# Altium schematic sheet Schematics.SchDoc
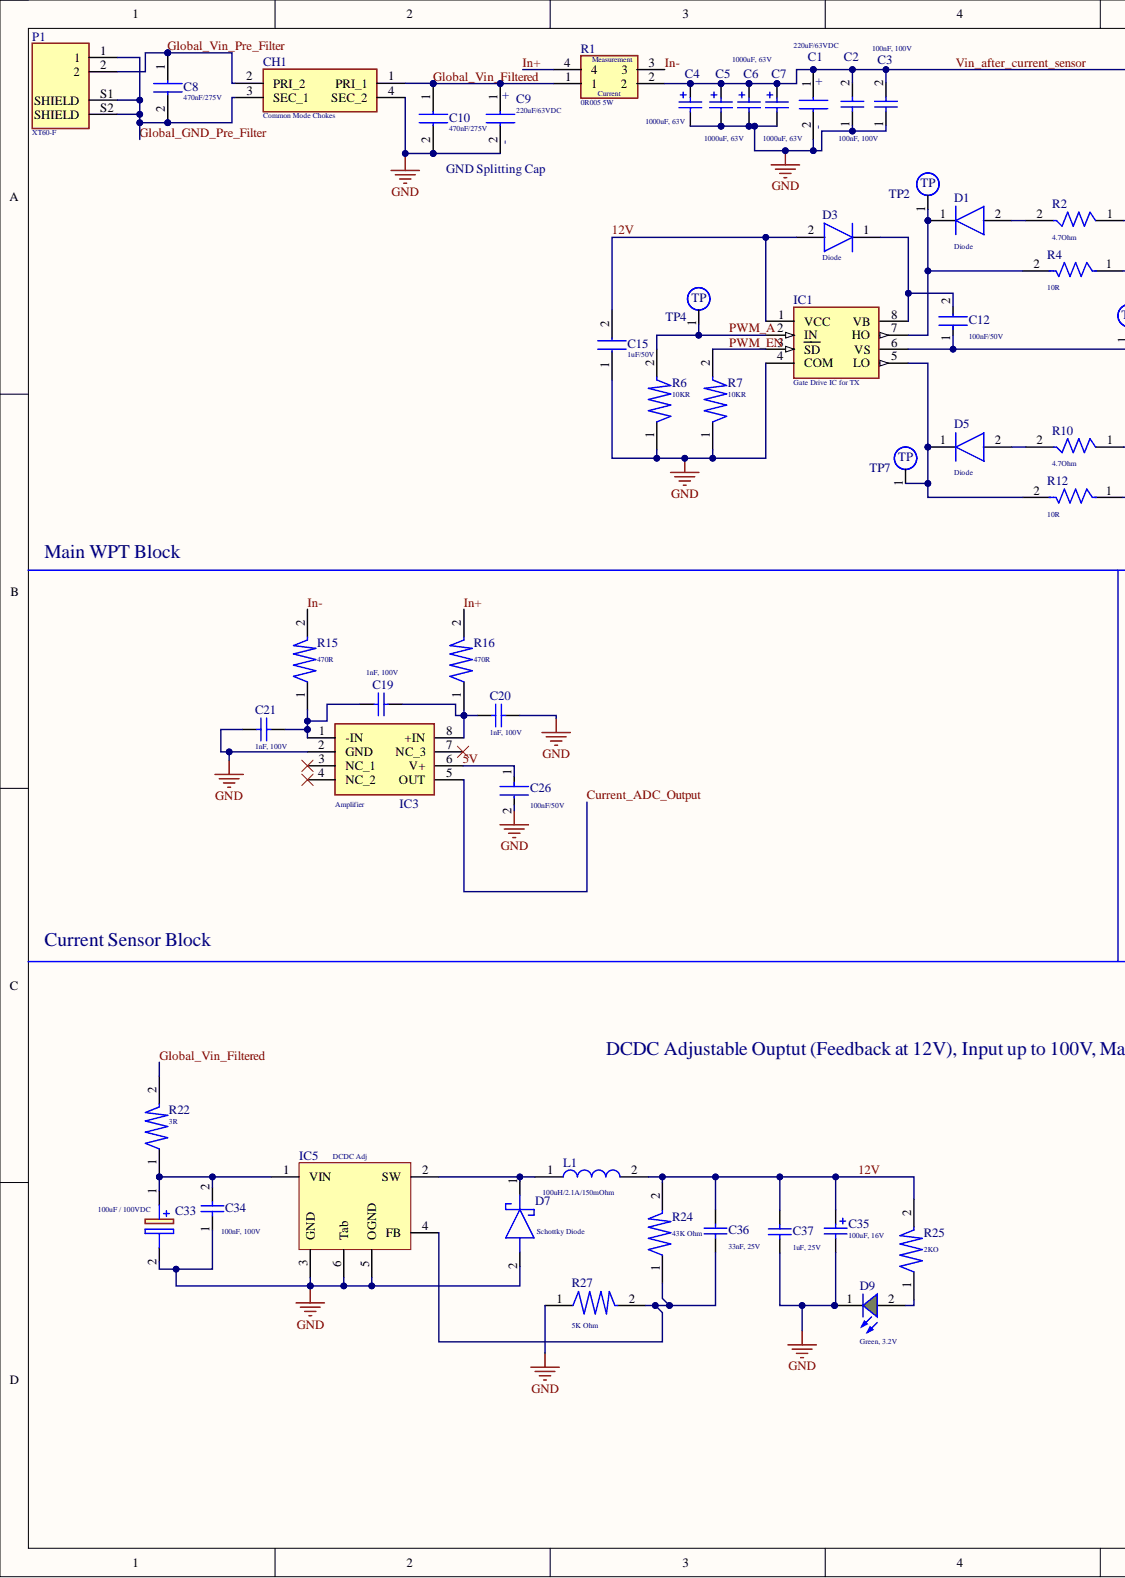
[diagram p0: Schematics.SchDoc, left]
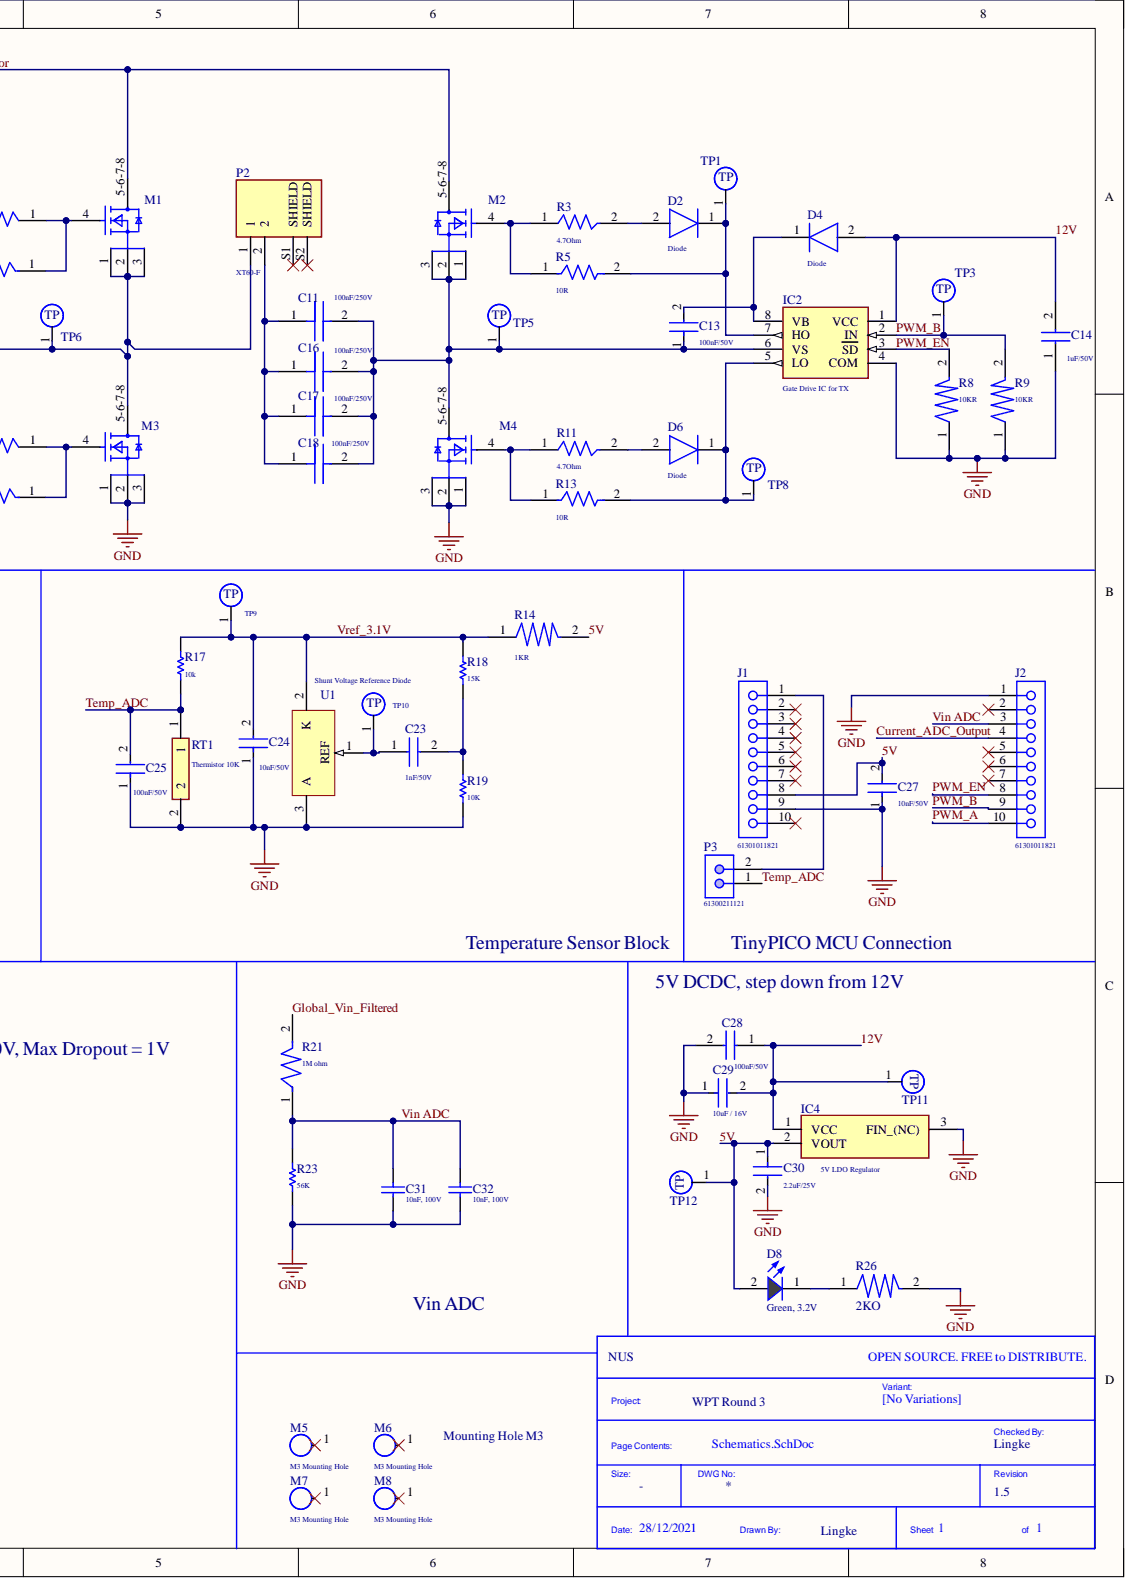
[diagram p1: Schematics.SchDoc, right]

## Parts
*1  Checked By: Lingke  Company: NUS  Project Name: WPT Round 3  Revision: 1.5  (hidden comment: Title_Block)  (no BOM)
C1  220uF/63VDC  [CAPPRD500W62D1000H2650]
C2  100nF, 100V  [WCAP-CSGP_0805_H=1.25mm]
C3  100nF, 100V  [WCAP-CSGP_0805_H=1.25mm]
C4  1000uF, 63V  [WCAP-ATG8_D16H25]
C5  1000uF, 63V  [WCAP-ATG8_D16H25]
C6  1000uF, 63V  [WCAP-ATG8_D16H25]
C7  1000uF, 63V  [WCAP-ATG8_D16H25]
C8  470nF/275V  [890324025039CS]
C9  220uF/63VDC  [CAPPRD500W62D1000H2650]
C10  470nF/275V  [890324025039CS]
C11  100nF/250V  [CAPC4532X220N]
C12  100nF/50V  [0805 Capacitor]
C13  100nF/50V  [0805 Capacitor]
C14  1uF/50V  [CAPC2012X135N]
C15  1uF/50V  [CAPC2012X135N]
C16  100nF/250V  [CAPC4532X220N]
C17  100nF/250V  [CAPC4532X220N]
C18  100nF/250V  [CAPC4532X220N]
C19  1nF, 100V  [WCAP-CSGP_0805_H=0.8mm_Reflow]
C20  1nF, 100V  [WCAP-CSGP_0805_H=0.8mm_Reflow]
C21  1nF, 100V  [WCAP-CSGP_0805_H=0.8mm_Reflow]
C23  1nF/50V  [CAPC2012X90N]
C24  10nF/50V  [0805 Capacitor]
C25  100nF/50V  [0805 Capacitor]
C26  100nF/50V  [0805 Capacitor]
C27  10nF/50V  [0805 Capacitor]
C28  100nF/50V  [CAPC2012X90N]
C29  10uF / 16V  [0805 Capacitor]
C30  2.2uF/25V  [0805 Capacitor]
C31  10nF, 100V  [WCAP-CSGP_0805_H=0.8mm_Reflow]
C32  10nF, 100V  [WCAP-CSGP_0805_H=0.8mm_Reflow]
C33  100uF / 100VDC  [CAPPRD500W60D1000H2200]
C34  100nF, 100V  [WCAP-CSGP_0805_H=1.25mm]
C35  100uF, 16V  [WCAP-ASLI_D6.3H5.5]
C36  33nF, 25V  [WCAP-CSGP_0805_H=0.8mm_Reflow]
C37  1uF, 25V  [WCAP-CSGP_0805_H=1.25mm]
CH1  Common Mode Chokes  [PA5141205NLT]
D1  Diode  [SOD3716X135N]
D2  Diode  [SOD3716X135N]
D3  Diode  [SOD3716X135N]
D4  Diode  [SOD3716X135N]
D5  Diode  [SOD3716X135N]
D6  Diode  [SOD3716X135N]
D7  Schottky Diode  [DIONM8059X265N]
D8  Green, 3.2V  Forward Voltage typ.: 3.2V  [WL-SMCW_0805]
D9  Green, 3.2V  Forward Voltage typ.: 3.2V  [WL-SMCW_0805]
IC1  Gate Drive IC for TX  [SOIC127P600X175-8N]
IC2  Gate Drive IC for TX  [SOIC127P600X175-8N]
IC3  Amplifier  [SOIC127P600X175-8N]
IC4  5V LDO Regulator  [ROHM_TO-252-3]
IC5  DCDC Adj  [TO-263-5]
J1  61301011821  [61301011821]
J2  61301011821  [61301011821]
L1  100uH/2.1A/150mOhm  [INDPM125125X800N]
M1  Mosfet  [FP-PG-TDSON-8-MFG]  (value not drawn)
M2  Mosfet  [FP-PG-TDSON-8-MFG]  (value not drawn)
M3  Mosfet  [FP-PG-TDSON-8-MFG]  (value not drawn)
M4  Mosfet  [FP-PG-TDSON-8-MFG]  (value not drawn)
M5  M3 Mounting Hole  [M3 Hole]
M6  M3 Mounting Hole  [M3 Hole]
M7  M3 Mounting Hole  [M3 Hole]
M8  M3 Mounting Hole  [M3 Hole]
P1  XT60-F  [AMASS_XT60PW-F]
P2  XT60-F  [AMASS_XT60PW-F]
P3  61300211121  [61300211121]
R1  0R005 5W  [FC4L110R005FER]
R2  4.7Ohm  [FP-CRCW1206-e3-IPC_A]
R3  4.7Ohm  [FP-CRCW1206-e3-IPC_A]
R4  10R  [FP-CRCW1206-e3-IPC_A]
R5  10R  [FP-CRCW1206-e3-IPC_A]
R6  10KR  [FP-CRCW0805-e3-MFG]
R7  10KR  [FP-CRCW0805-e3-MFG]
R8  10KR  [FP-CRCW0805-e3-MFG]
R9  10KR  [FP-CRCW0805-e3-MFG]
R10  4.7Ohm  [FP-CRCW1206-e3-IPC_A]
R11  4.7Ohm  [FP-CRCW1206-e3-IPC_A]
R12  10R  [FP-CRCW1206-e3-IPC_A]
R13  10R  [FP-CRCW1206-e3-IPC_A]
R14  1KR  [FP-CRCW0805-e3-MFG]
R15  470R  [FP-CRCW0805-e3-MFG]
R16  470R  [FP-CRCW0805-e3-MFG]
R17  10k  [0805 Resistor]
R18  15K  [0805 Resistor]
R19  10K  [0805 Resistor]
R21  1M ohm  [FP-CRCW0805-e3-MFG]
R22  3R  [1206_Resistor]
R23  56K  [0805 Resistor]
R24  43K Ohm  [FP-CRCW0805-e3-MFG]
R25  2KO  [FP-CRCW0805-e3-MFG]
R26  2KO  [FP-CRCW0805-e3-MFG]
R27  5K Ohm  [FP-CRCW0805-e3-MFG]
RT1  Thermistor 10K  [THRMC2012X95N]
TP1  TP  [Test Point]  (no BOM)
TP2  TP  [Test Point]  (no BOM)
TP3  TP  [Test Point]  (no BOM)
TP4  TP  [Test Point]  (no BOM)
TP5  TP  [Test Point]  (no BOM)
TP6  TP  [Test Point]  (no BOM)
TP7  TP  [Test Point]  (no BOM)
TP8  TP  [Test Point]  (no BOM)
TP9  TP  [Test Point]  (no BOM)
TP10  TP  [Test Point]  (no BOM)
TP11  TP  [Test Point]  (no BOM)
TP12  TP  [Test Point]  (no BOM)
U1  Shunt Voltage Reference Diode  [SOT23-3_N]

## Nets
GND: C1.2 C2.1 C3.1 C4.2 C5.2 C6.2 C7.2 C9.2 C10.2 C14.1 C15.1 C20.2 C21.1 C24.1 C25.1 C26.2 C27.1 C28.2 C29.1 C30.2 C31.1 C32.1 C33.2 C34.1 C35.2 C37.1 CH1.4(SEC_2) D7.2 D9.1 IC1.4(COM) IC2.4(COM) IC3.2(GND) IC4.3 IC5.3(GND) IC5.5(OGND) IC5.6(Tab) J1.9 J2.1 M3.1 M3.2 M3.3 M4.1 M4.2 M4.3 R6.1 R7.1 R8.1 R9.1 R19.1 R23.1 R26.2 R27.1 RT1.2 U1.3
5V: C26.1 C27.2 C30.1 D8.2 IC3.6(V+) IC4.2 J1.8 R14.2 TP12.1
12V: C14.2 C15.2 C28.1 C29.2 C35.1 C36.2 C37.2 D3.2 D4.2 IC1.1(VCC) IC2.1(VCC) IC4.1 L1.2 R24.2 R25.2 TP11.1
Current_ADC_Output: IC3.5(OUT) J2.4
Global_GND_Pre_Filter: C8.2 CH1.3(SEC_1) P1.1 P1.S1(SHIELD) P1.S2(SHIELD)
Global_Vin_Filtered: C9.1 C10.1 CH1.1(PRI_1) R1.1 R21.2 R22.2
Global_Vin_Pre_Filter: C8.1 CH1.2(PRI_2) P1.2
In+: R1.4 R16.2
In-: R1.3 R15.2
PWM_A: IC1.2(IN) J2.10 R6.2 TP4.1
PWM_B: IC2.2(IN) J2.9 R9.2 TP3.1
PWM_EN: IC1.3(SD) IC2.3(SD) J2.8 R7.2 R8.2
Temp_ADC: C25.2 P3.1 R17.1 RT1.1
Vin ADC: C31.2 C32.2 J2.3 R21.1 R23.2
Vin_after_current_sensor: C1.1 C2.2 C3.2 C4.1 C5.1 C6.1 C7.1 M1.5-6-7-8 M2.5-6-7-8 R1.2
Vref_3.1V: C24.2 R14.1 R17.2 R18.2 TP9.1 U1.2
NetC11_1: C11.1 C16.1 C17.1 C18.1 P2.2
NetC11_2: C11.2 C13.1 C16.2 C17.2 C18.2 IC2.6(VS) M2.1 M2.2 M2.3 M4.5-6-7-8 TP5.1
NetC12_1: C12.1 IC1.6(VS) M1.1 M1.2 M1.3 M3.5-6-7-8 P2.1 TP6.1
NetC12_2: C12.2 D3.1 IC1.8(VB)
NetC13_2: C13.2 D4.1 IC2.8(VB)
NetC19_1: C19.1 C21.2 IC3.1(-IN) R15.1
NetC19_2: C19.2 C20.1 IC3.8(+IN) R16.1
NetC23_1: C23.1 TP10.1 U1.1
NetC23_2: C23.2 R18.1 R19.2
NetC33_1: C33.1 C34.2 IC5.1(VIN) R22.1
NetC36_1: C36.1 IC5.4(FB) R24.1 R27.2
NetD1_1: D1.1 IC1.7(HO) R4.2 TP2.1
NetD1_2: D1.2 R2.2
NetD2_1: D2.1 IC2.7(HO) R5.2 TP1.1
NetD2_2: D2.2 R3.2
NetD5_1: D5.1 IC1.5(LO) R12.2 TP7.1
NetD5_2: D5.2 R10.2
NetD6_1: D6.1 IC2.5(LO) R13.2 TP8.1
NetD6_2: D6.2 R11.2
NetD7_1: D7.1 IC5.2(SW) L1.1
NetD8_1: D8.1 R26.1
NetD9_2: D9.2 R25.1
NetJ1_1: J1.1 P3.2
NetM1_4: M1.4 R2.1 R4.1
NetM2_4: M2.4 R3.1 R5.1
NetM3_4: M3.4 R10.1 R12.1
NetM4_4: M4.4 R11.1 R13.1

Not connected: IC3 3(NC_1) 4(NC_2) 7(NC_3); J1 2 3 4 5 6 7 10; J2 2 5 6 7; M5 1; M6 1; M7 1; M8 1; P2 S1(SHIELD) S2(SHIELD)

## Library data of the parts
*1 = Title_Block: Standard Title Block.; Library Name: Symbol Library.SchLib; Size: -; Drawn By: Lingke
C1 C9 = 860040775010: Polarised Cap, 220uF/63VDC; Manufacturer_Name: Wurth Elektronik; Component Kind: Standard; Datasheet Link: https://www.mouser.de/datasheet/2/445/860040775010-1725627.pdf; Height: 26.5mm; Library Name: Symbol Library.SchLib; Manufacturer_Part_Number: 860040775010
C2 C3 C34 = 885012207128: WCAP-CSGP Multilayer Ceramic Chip Capacitor, General Purpose, size 0805, X7R, 100nF, 100V; Manufacturer: Wurth Elektronik; Capacitance Tolerance: +/-10%; Dissipation Factor: #NAME?; Isolation Resistance: >=1GOhm; Rated Voltage: 100VDC; Technical Reference: X7R0805104K100DFCT10000; Capacitance: 100nF; Ceramic Type: X7R; Component Kind: Standard; ComponentLink1Description: Manufacturer; ComponentLink1URL: http://www.we-online.de; ComponentLink2Description: Datasheet; ComponentLink2URL: https://www.we-online.com/catalog/datasheet/885012207128.pdf; Height: 1.25mm; Library Name: Symbol Library.SchLib; Manufacturer Part Number: 885012207128; Mounting Technology: SMT; Operating Temperature: -55 to +125degC; Packaging: Tape and Reel; Published Date: May 2020; Size: 0805
C4-C7 = 860010780024: WCAP-ATG8 Aluminum Electrolytic Capacitor, Radial, THT, D16xH25, 1000uF, 63V; Manufacturer: Wurth Elektronik; Capacitance Tolerance: +/-20%; Dissipation Factor: 9%; Endurance: 2000h; Leakage Current: 630uA; Rated Voltage: 63VDC; Ripple Current: 1540mA; Technical Reference: ATBK250102M063DSPAEB000; Capacitance: 1000uF; Component Kind: Standard; ComponentLink1Description: Manufacturer; ComponentLink1URL: http://www.we-online.de; ComponentLink2Description: Datasheet; ComponentLink2URL: https://www.we-online.com/catalog/datasheet/860010780024.pdf; Diameter: 16mm; Height: 25mm; Library Name: Altium_WCAP-ATG8 (rev20b).IntLib; Manufacturer Part Number: 860010780024; Mounting Technology: THT; Operating Temperature: -40 to +85degC; Packaging: Ammo Pack; Published Date: October 2020
C8 C10 = 890324025039CS: Safety Capacitor, 470nF/275V; Manufacturer_Name: Wurth Elektronik; Component Kind: Standard; Height: 14.5mm; Library Name: Symbol Library.SchLib; Manufacturer_Part_Number: 890324025039CS
C11 C16-C18 = C1812C104JAGACAUTO: Manufacturer_Name: Kemet; Component Kind: Standard; Datasheet Link: https://api.kemet.com/component-edge/download/datasheet/C1812C104JAGACAUTO.pdf; Description: 100nF/250V; Height: 2.2mm; Library Name: Symbol Library.SchLib; Manufacturer_Part_Number: C1812C104JAGACAUTO; Supplier 1: Mouser; Supplier Part Number 1: 80-C1812C104JAGAUTO
C12 C13 C25 C26 = 885012207098: Component Kind: Standard; Description: 100nF/50V; Library Name: Symbol Library.SchLib; Supplier 1: Mouser; Supplier Part Number 1: 710-885012207098
C14 C15 = 885012207103: Capacitor 1uF/50V; Manufacturer_Name: Wurth Elektronik; Component Kind: Standard; Datasheet Link: https://katalog.we-online.com/pbs/datasheet/885012207103.pdf; Height: 1.35mm; Library Name: Symbol Library.SchLib; Manufacturer_Part_Number: 885012207103; Supplier 1: Mouser; Supplier Part Number 1: 710-885012207103
C19-C21 = 885012207116: WCAP-CSGP Multilayer Ceramic Chip Capacitor, General Purpose, size 0805, X7R, 1nF, 100V; Manufacturer: Wurth Elektronik; Capacitance Tolerance: +/-10%; Dissipation Factor max.: 2.5%; Isolation Resistance  min.: 10GOhm; Rated Voltage: 100VDC; Technical Reference: X7R0805102K100DFCT10000; Capacitance: 1nF; Ceramic Type: X7R; Component Kind: Standard; ComponentLink1Description: Manufacturer; ComponentLink1URL: http://www.we-online.de; ComponentLink2Description: Datasheet; ComponentLink2URL: https://www.we-online.com/catalog/datasheet/885012207116.pdf; Height: 0.8mm; Library Name: Altium_WCAP-CSGP (rev21d).IntLib; Manufacturer Part Number: 885012207116; Mounting Technology: SMT; Operating Temperature: -55 to +125degC; Packaging: Tape and Reel; Published Date: April 2021; Q-Factor min.: -; Size: 0805
C23 = 885012207086: Manufacturer_Name: Wurth Elektronik; Component Kind: Standard; Datasheet Link: https://katalog.we-online.com/pbs/datasheet/885012207086.pdf; Description: 1nF/50V; Height: 0.9mm; Library Name: Symbol Library.SchLib; Manufacturer_Part_Number: 885012207086; Supplier 1: Mouser; Supplier Part Number 1: 710-885012207086
C24 C27 = 885012207092: Component Kind: Standard; Description: 10nF/50V; Library Name: Symbol Library.SchLib; Supplier 1: Mouser; Supplier Part Number 1: 710-885012207092
C28 = 885012207098: Manufacturer_Name: Wurth Elektronik; Component Kind: Standard; Description: 100nF/50V; Height: 0.9mm; Library Name: Symbol Library.SchLib; Supplier 1: Mouser; Supplier Part Number 1: 710-885012207098
C29 = CL21A106KOQNNNE: 100nF/50V; Component Kind: Standard; Supplier 1: Mouser; Supplier Part Number 1: 710-885012207098; Library Name: WPT NUS.IntLib
C30 = 885012207079: Capacitance: 2.2; Dielectric: X5R; Voltage Rating (DC): 25V; Case Code (Imperial): 0805; Case Code (Metric): 2012; Case/Package: 0805; Component Kind: Standard; Description: 2.2uF/25V; Library Name: Symbol Library.SchLib; Max Operating Temperature: 125°C; Package Quantity: 2000; Supplier 1: Mouser; Supplier Part Number 1: 710-885012207079
C31 C32 = 885012207122: WCAP-CSGP Multilayer Ceramic Chip Capacitor, General Purpose, size 0805, X7R, 10nF, 100V; Manufacturer: Wurth Elektronik; Capacitance Tolerance: +/-10%; Dissipation Factor max.: 2.5%; Isolation Resistance  min.: 10GOhm; Rated Voltage: 100VDC; Technical Reference: X7R0805103K100DFCT10000; Capacitance: 10nF; Ceramic Type: X7R; Component Kind: Standard; ComponentLink1Description: Manufacturer; ComponentLink1URL: http://www.we-online.de; ComponentLink2Description: Datasheet; ComponentLink2URL: https://www.we-online.com/catalog/datasheet/885012207122.pdf; Height: 0.8mm; Library Name: Altium_WCAP-CSGP (rev21d).IntLib; Manufacturer Part Number: 885012207122; Mounting Technology: SMT; Operating Temperature: -55 to +125degC; Packaging: Tape and Reel; Published Date: April 2021; Q-Factor min.: -; Size: 0805
C33 = ESH107M100AH4AA: Capacitor Polarised; Manufacturer_Name: Kemet; Component Kind: Standard; Datasheet Link: https://componentsearchengine.com/Datasheets/1/ESH107M100AH4AA.pdf; Height: 22mm; Manufacturer_Part_Number: ESH107M100AH4AA; Mouser Part Number: 80-ESH107M100AH4AA; Mouser Price/Stock: https://www.mouser.co.uk/ProductDetail/KEMET/ESH107M100AH4AA?qs=gt1LBUVyoHkVRbiIYuZpEw%3D%3D; Library Name: WPT NUS.IntLib
C35 = 865080343009: WCAP-ASLI Aluminum Electrolytic Capacitor, V-Chip, SMT, D6.3xH5.5mm, 100uF, 16V; Manufacturer: Wurth Elektronik; Capacitance Tolerance: +/-20%; Dissipation Factor: 16%; Endurance: 2000h; Leakage Current: 16uA; Rated Voltage: 16VDC; Ripple Current: 255mA; Technical Reference: ASED055101M016DVCTBB000; Capacitance: 100uF; Component Kind: Standard; ComponentLink1Description: Manufacturer; ComponentLink1URL: http://www.we-online.de; ComponentLink2Description: Datasheet; ComponentLink2URL: https://www.we-online.com/catalog/datasheet/865080343009.pdf; Diameter: 6.3mm; Height: 5.5mm; Library Name: Altium_WCAP-ASLI (rev20b) (1).IntLib; Manufacturer Part Number: 865080343009; Mounting Technology: SMT; Operating Temperature: -55 to +105degC; Packaging: Tape and Reel; Published Date: October 2020
C36 = 885012207069: WCAP-CSGP Multilayer Ceramic Chip Capacitor, General Purpose, size 0805, X7R, 33nF, 25V; Manufacturer: Wurth Elektronik; Capacitance Tolerance: +/-10%; Dissipation Factor max.: 3.5%; Isolation Resistance  min.: 10GOhm; Rated Voltage: 25VDC; Technical Reference: X7R0805333K025DFCT10000; Capacitance: 33nF; Ceramic Type: X7R; Component Kind: Standard; ComponentLink1Description: Manufacturer; ComponentLink1URL: http://www.we-online.de; ComponentLink2Description: Datasheet; ComponentLink2URL: https://www.we-online.com/catalog/datasheet/885012207069.pdf; Height: 0.8mm; Library Name: Altium_WCAP-CSGP (rev21d).IntLib; Manufacturer Part Number: 885012207069; Mounting Technology: SMT; Operating Temperature: -55 to +125degC; Packaging: Tape and Reel; Published Date: April 2021; Q-Factor min.: -; Size: 0805
C37 = 885012207078: WCAP-CSGP Multilayer Ceramic Chip Capacitor, General Purpose, size 0805, X7R, 1uF, 25V; Manufacturer: Wurth Elektronik; Capacitance Tolerance: +/-10%; Dissipation Factor max.: 5%; Isolation Resistance  min.: 0.5GOhm; Rated Voltage: 25VDC; Technical Reference: X7R0805105K025DFCT10000; Capacitance: 1uF; Ceramic Type: X7R; Component Kind: Standard; ComponentLink1Description: Manufacturer; ComponentLink1URL: http://www.we-online.de; ComponentLink2Description: Datasheet; ComponentLink2URL: https://www.we-online.com/catalog/datasheet/885012207078.pdf; Height: 1.25mm; Library Name: Altium_WCAP-CSGP (rev21d).IntLib; Manufacturer Part Number: 885012207078; Mounting Technology: SMT; Operating Temperature: -55 to +125degC; Packaging: Tape and Reel; Published Date: April 2021; Q-Factor min.: -; Size: 0805
CH1 = PA5141.205NLT: Filter; Manufacturer_Name: Pulse; Component Kind: Standard; Datasheet Link: https://products.pulseelex.com/files/product_files/P860.pdf; Height: 22.7mm; Library Name: Symbol Library.SchLib; Manufacturer_Part_Number: PA5141.205NLT; Mouser Part Number: 673-PA5141.205NLT; Mouser Price/Stock: https://www.mouser.co.uk/ProductDetail/Pulse-Electronics/PA5141205NLT?qs=l7cgNqFNU1hhs8iQ7nyooA%3D%3D
D1-D6 = 1N4148W-E3-08: Manufacturer_Name: Vishay; Component Kind: Standard; Datasheet Link: https://componentsearchengine.com//1N4148W-E3-08.pdf; Description: Diode; Height: 1.35mm; Library Name: Symbol Library.SchLib; Manufacturer_Part_Number: 1N4148W-E3-08; Mouser2 Part Number: 78-1N4148W-E3-08; Mouser2 Price/Stock: https://www.mouser.com/Search/Refine.aspx?Keyword=78-1N4148W-E3-08; Supplier 1: Digi-Key; Supplier Part Number 1: 1N4148W-E3-08CT-ND
D7 = S310: Manufacturer_Name: ON Semiconductor; Arrow Part Number: S310; Arrow Price/Stock: https://www.arrow.com/en/products/s310/on-semiconductor?region=nac; Component Kind: Standard; Datasheet Link: https://componentsearchengine.com/Datasheets/2/S310.pdf; Description: Schottky Diode; Height: 2.65mm; Library Name: Symbol Library.SchLib; Manufacturer_Part_Number: S310; Mouser Part Number: 512-S310; Mouser Price/Stock: https://www.mouser.co.uk/ProductDetail/ON-Semiconductor-Fairchild/S310?qs=AZz8fAaf6P%2FJSlbiibJLxA%3D%3D
D8 D9 = 150080GS75000: WL-SMCW SMT Mono-color Chip LED Waterclear, size 0805, Green, 3.2V, 140deg; Chip Technology: InGaN; Dominant Wavelength typ.: 525nm; Luminous Intensity typ.: 450mcd; Peak Wavelength typ.: 515nm; Component Kind: Standard; ComponentLink1Description: Manufacturer; ComponentLink1URL: http://www.we-online.de; ComponentLink2Description: Datasheet; ComponentLink2URL: https://www.we-online.com/catalog/datasheet/150080GS75000.pdf; Emitting Color: Green; Library Name: Altium_WL-SMCW (rev20a).IntLib; Mounting Technology: SMT; Operating Temperature: -40 to +85degC; Packaging: Tape and Reel; Part Number: 150080GS75000; Published Date: December 2019; Size: 0805; Viewing Angle: 140deg; Publisher: Wurth Elektronik
IC1 IC2 = IRS2008SPBF: Gate Drive IC; Manufacturer_Name: Infineon; Component Kind: Standard; Height: 1.75mm; Library Name: Symbol Library.SchLib; Manufacturer_Part_Number: IRS2008SPBF; Supplier 1: Mouser; Supplier Part Number 1: 726-IRS2008SPBF
IC3 = AD8215YRZ: Integrated Circuit Amplifier; Manufacturer_Name: Analog Devices; Component Kind: Standard; Datasheet Link: http://www.analog.com/static/imported-files/data_sheets/AD8215.pdf; Height: 1.75mm; Library Name: Symbol Library.SchLib; Manufacturer_Part_Number: AD8215YRZ; Supplier 1: Mouser; Supplier Part Number 1: 584-AD8215YRZ
IC4 = BD450M5FP-CE2: Manufacturer_Name: ROHM Semiconductor; Component Kind: Standard; Datasheet Link: https://componentsearchengine.com//BD450M5FP-CE2.pdf; Description: 5V LDO Regulator; Library Name: Symbol Library.SchLib; Manufacturer_Part_Number: BD450M5FP-CE2; Mouser2 Part Number: 755-BD450M5FP-CE2; Mouser2 Price/Stock: https://www.mouser.com/Search/Refine.aspx?Keyword=755-BD450M5FP-CE2
IC5 = XL7035E1: Component Kind: Standard; Library Name: Symbol Library.SchLib
J1 J2 = 61301011821: WR-PHD Socket Header, THT, pitch 2.54mm, Single Row, Vertical, 10p; Manufacturer: Wurth Elektronik; Contact Resistance: 20mOhm; Pin Number: 10; Rated Current: 3A; Withstanding Voltage: 500VAC; Working Voltage: 250VAC; Component Kind: Standard; ComponentLink1Description: Manufacturer; ComponentLink1URL: http://www.we-online.de; ComponentLink2Description: Datasheet; ComponentLink2URL: https://www.we-online.com/catalog/datasheet/61301011821.pdf; Gender: Socket Header; Library Name: Altium_WR-PHD (rev20a).IntLib; Manufacturer Part Number: 61301011821; Mounting Technology: THT; Operating Temperature: -40 to +125degC; Orientation: Vertical; Packaging: Tray; Pitch: 2.54mm; Published Date: May 2020; Row Number: Single
L1 = SRR1280-101M: Inductor; Manufacturer_Name: Bourns; Arrow Part Number: SRR1280-101M; Arrow Price/Stock: https://www.arrow.com/en/products/srr1280-101m/bourns; Component Kind: Standard; Datasheet Link: https://componentsearchengine.com/Datasheets/2/SRR1280-101M.pdf; Height: 8mm; Library Name: Symbol Library.SchLib; Manufacturer_Part_Number: SRR1280-101M; Mouser Part Number: 652-SRR1280-101M; Mouser Price/Stock: https://www.mouser.co.uk/ProductDetail/Bourns/SRR1280-101M?qs=brMhP%2FMjUfd8D85Ep5aW7g%3D%3D
M1-M4 = BSC040N10NS5ATMA1: MOSFET N-CH 100V 100A 8TDSON  Mosfet Switcher; Manufacturer: Infineon; Depletion Mode: False; Drain To Source Resistance: 3.4mΩ; Gate Charge At Vgs: 58nC @ 10V; Gate To Source Voltage: 20V; Input Capacitace At Vds: 4100pF @ 50V; Max Forward Diode Voltage: 1.1V; Max Junction Temp: +150°C; Number Of Channels: 1; Power Dissipation: 139W; Pulse Drain Current: 400A; Reverse Recovery Charge: 90nC; Reverse Recovery Time: 54ns; Rthja Max: 50K/W; Threshold Vgs Max: 3.8V; Threshold Vgs Min: 2.2V; Transconductance: 120S; Turn Off Delay Time: 32ns; Turn On Delay Time: 13ns; Typ Forward Diode Voltage: 0.9V; Automotive: No; Category: Trans; Component Kind: Standard; ComponentLink2Description: Datasheet; ComponentLink2URL: https://www.infineon.com/dgdl/Infineon-BSC040N10NS5-DS-v02_02-EN.pdf?fileId=5546d4624a0bf290014a0fbcee2e6b38; Continuous Drain Current: 100A; Device Class L1: Discrete Semiconductors; Device Class L2: Transistors; Device Class L3: MOSFETs; Digikey Description: MOSFET N-CH 100V 100A 8TDSON; Drain To Source Breakdown Voltage: 100V; Drain To Source Voltage: 100V; Footprint Url: https://www.infineon.com/dgdl/Infineon-PG-TDSON-8-7-FP-v01_00-EN.pdf?fileId=5546d46259d9a4bf015a1b6adadd1f0a; Height: 1.1mm; Lead Free: Yes; Library Name: Symbol Library.SchLib; Manufacturer Part Number: BSC040N10NS5ATMA1; Mouser Description: MOSFET N-Ch 100V 100A TDSON-8; Number Of N Channels: 1; Octopart: https://octopart.com/bsc040n10ns5atma1-infineon-52825711; Package: PG-TDSON-8; RoHS: TRUE; Supplier 1: Mouser; Supplier Part Number 1: 726-BSC040N10NS5ATMA; Temperature: -55°C to +150°C; Temperature Range High: +150°C; Temperature Range Low: -55°C
M5-M8 = M3_Mounting_hole: Component Kind: Standard; Library Name: Symbol Library.SchLib
P1 P2 = XT60PW-F: Socket DC Supply Connector Female; MF: AMASS; Availability: Unavailable; BuyPart: https://www.snapeda.com/parts/XT60PW-F/AMASS/view-part/2890674/?ref=eda; Component Kind: Standard; Library Name: Symbol Library.SchLib; MP: XT60PW-F; Package: Package; Price: None; SnapEDA_Link: https://www.snapeda.com/parts/XT60PW-F/AMASS/view-part/2890674/?ref=snap
P3 = 61300211121: WR-PHD Pin Header, THT, pitch 2.54mm, Single Row, Vertical, 2p; Manufacturer: Wurth Elektronik; Contact Resistance: 20mOhm; Pin Number: 2; Rated Current: 3A; Withstanding Voltage: 500VAC; Working Voltage: 250VAC; Component Kind: Standard; ComponentLink1Description: Manufacturer; ComponentLink1URL: http://www.we-online.de; ComponentLink2Description: Datasheet; ComponentLink2URL: https://www.we-online.com/catalog/datasheet/61300211121.pdf; Gender: Pin Header; Library Name: Altium_WR-PHD (rev20a).IntLib; Manufacturer Part Number: 61300211121; Mounting Technology: THT; Operating Temperature: -40 to +105degC; Orientation: Vertical; Packaging: Bag; Pitch: 2.54mm; Published Date: May 2020; Row Number: Single
R1 = FC4L110R005FER: Resistor 0R005 5W; Manufacturer_Name: Ohmite; Component Kind: Standard; Height: 0.7mm; Library Name: Symbol Library.SchLib; Manufacturer_Part_Number: FC4L110R005FER; Supplier 1: Mouser; Supplier Part Number 1: 588-FC4L110R005FER
R2 R3 R10 R11 = CRCW12064R70FKEA: RES Thick Film, 4.7Ω, 1%, 0.25W, 100ppm/°C, 1206  MOSFET Gate Fast-off Resistor 4.7Ohm; Max Power Dissipation: 250mW; Resistance: 4.7R; Voltage Rating: 200V; Case Code (Imperial): 1206; Case Code (Metric): 3216; Case/Package: 1206; Component Kind: Standard; Composition: Thick Film; Contact Plating: Tin; Depth: 1.6mm; Features: Automotive AEC-Q200; Height: 0.024inch; Length: 3.2mm; Library Name: Symbol Library.SchLib; Max Operating Temperature: 155°C; Military Standard: Not; Min Operating Temperature: -55°C; Mount: Surface Mount; Number of Pins: 2; Number of Terminations: 2; Package Quantity: 10; Packaging: Tape and Reel; Power Rating: 250mW; Radiation Hardening: No; Supplier 1: Mouser; Supplier Part Number 1: 71-CRCW12064R70FKEA; Temperature Coefficient: 100ppm/°C; Tolerance: 1%; Weight: 0.000353oz; Width: 0.063inch
R4 R5 R12 R13 = CRCW120610R0FKEA: RES Thick Film, 10Ω, 1%, 0.25W, 100ppm/°C, 1206  MOSFET Gate Resistor 10Ohm; Max Power Dissipation: 250mW; Voltage Rating: 200V; Case Code (Imperial): 1206; Case Code (Metric): 3216; Case/Package: 1206; Component Kind: Standard; Composition: Thick Film; Contact Plating: Tin; Depth: 1.6mm; Features: Automotive AEC-Q200; Height: 0.55mm; Lead Free: Lead Free; Length: 3.2mm; Library Name: Symbol Library.SchLib; Max Operating Temperature: 155°C; Military Standard: Not; Min Operating Temperature: -55°C; Number of Pins: 2; Number of Terminations: 2; Package Quantity: 10; Packaging: Tape and Reel; Power Rating: 250mW; Radiation Hardening: No; Resistance: 10R; Supplier 1: Digi-Key; Supplier Part Number 1: 541-10.0FDKR-ND; Temperature Coefficient: 100ppm/°C; Termination: SMD/SMT; Tolerance: 1%; Voltage Rating (DC): 200V; Weight: 0.000353oz; Width: 0.063inch
R6-R9 = CRCW080510K0FKEA: Resistor 10K Ohm; Component Kind: Standard; Library Name: Symbol Library.SchLib; Number of Terminations: 2; Supplier 1: Mouser; Supplier Part Number 1: 71-CRCW0805-10K-E3
R14 = CRCW08051K00FKEA: Resistor 1k Ohm; Component Kind: Standard; Library Name: Symbol Library.SchLib; Number of Terminations: 2; Supplier 1: Mouser; Supplier Part Number 1: 71-CRCW0805-1.0K-E3
R15 R16 = CRCW0805470RFKEB: Resistor 470 Ohm; Component Kind: Standard; Library Name: Symbol Library.SchLib; Number of Terminations: 2; Supplier 1: Mouser; Supplier Part Number 1: 71-CRCW0805470RFKEB
R17 = ERA-6ARB103V: High Presition and High reliability 10K Ohm Resistor; Component Kind: Standard; Library Name: Symbol Library.SchLib; Supplier 1: Mouser; Supplier Part Number 1: 667-ERA-6ARB103V
R18 = ERA-6ARW153V: High Presicion 15K Ohm; Component Kind: Standard; Library Name: Symbol Library.SchLib; Supplier 1: Mouser; Supplier Part Number 1: 667-ERA-6ARW153V
R19 = CRCW080510K0FKEB: High Presicion 10K Ohm; Component Kind: Standard; Library Name: Symbol Library.SchLib; Supplier 1: Newark; Supplier Part Number 1: 26R4046
R21 = CRCW08051M00FKEA: RES Thick Film, 1MΩ, 1%, 0.125W, 100ppm/°C, 0805  1M Ohm Resistor; Power Rating: 125mW; Resistance: 1MR; Voltage Rating: 150V; Case Code (Imperial): 0805; Case Code (Metric): 2012; Case/Package: 0805; Component Kind: Standard; Composition: Thick Film; Contact Plating: Tin; Depth: 1.25mm; Height: 0.45mm; Lead Free: Lead Free; Length: 2mm; Library Name: Symbol Library.SchLib; Max Operating Temperature: 155°C; Military Standard: Not; Min Operating Temperature: -55°C; Mount: Surface Mount; Number of Pins: 2; Package Quantity: 5000; Packaging: Tape and Reel; Radiation Hardening: No; Supplier 1: Mouser; Supplier Part Number 1: 71-CRCW08051M00FKEAH; Temperature Coefficient: 100ppm/°C; Termination: SMD/SMT; Tolerance: 1%; Voltage Rating (DC): 150V; Weight: 0.000194oz; Width: 1.25mm
R22 = CRCW12063R00FKEAHP: Resistor 3 Ohm; Component Kind: Standard; Number of Terminations: 2; Supplier 1: Mouser; Supplier Part Number 1: 71-CRCW0805-10K-E3; Library Name: WPT NUS.IntLib
R23 = ERJ-6ENF5622V: 56K Ohm Resistor; Component Kind: Standard; Library Name: Symbol Library.SchLib; Supplier 1: Mouser; Supplier Part Number 1: 667-ERJ-6ENF5622V
R24 = RC0805FR-0743KL: Resistor 43K Ohm; Component Kind: Standard; Library Name: Symbol Library.SchLib; Number of Terminations: 2; Supplier 1: Mouser; Supplier Part Number 1: 71-CRCW08051K30FKEB
R25 R26 = CRCW08052K00FKEB: RES Thick Film, 2kΩ, 1%, 0.125W, 100ppm/°C, 0805; Max Power Dissipation: 125mW; Resistance: 2kR; Voltage Rating: 150V; Case Code (Imperial): 0805; Case Code (Metric): 2012; Case/Package: 0805; Component Kind: Standard; Composition: Thick Film; Contact Plating: Tin; Features: Automotive AEC-Q200; Height: 0.02inch; Lead Free: Lead Free; Length: 0.079inch; Library Name: Symbol Library.SchLib; Max Operating Temperature: 155°C; Min Operating Temperature: -55°C; Number of Pins: 2; Number of Terminations: 2; Package Quantity: 10000; Packaging: Tape and Reel; Power Rating: 125mW; Radiation Hardening: No; Supplier 1: Mouser; Supplier Part Number 1: 71-CRCW08052K00FKEB; Temperature Coefficient: 100ppm/°C; Termination: SMD/SMT; Tolerance: 1%; Voltage Rating (DC): 150V; Weight: 0.000194oz; Width: 0.049inch
R27 = CRCW08055K00FKTA: Resistor 5K Ohm; Component Kind: Standard; Library Name: Symbol Library.SchLib; Number of Terminations: 2; Supplier 1: Mouser; Supplier Part Number 1: 71-CRCW08051K30FKEB
RT1 = NTCS0805E3103HMT: Thermistor 10Kohm B Parameter: 3950k; Manufacturer_Name: Vishay; Component Kind: Standard; Datasheet Link: http://www.vishay.com/docs/29044/ntcs0805e3t.pdf; Height: 0.95mm; Library Name: Symbol Library.SchLib; Manufacturer_Part_Number: NTCS0805E3103HMT; Supplier 1: Mouser; Supplier Part Number 1: 594-NTCS0805E3103HMT
TP1-TP12 = Test Point: Test Point 1mm by 1mm; Library Name: Symbol Library.SchLib
U1 = TS432AILT: Shunt voltage reference, adjustable, 1.24 to 10V, 0.5% precision, 3-Pin SOT23-3L, Tape and Reel; Component Kind: Standard; ComponentLink1Description: Manufacturer URL; ComponentLink1URL: http://www.st.com; ComponentLink2Description: Datasheet; ComponentLink2URL: http://www.st.com/internet/com/TECHNICAL_RESOURCES/TECHNICAL_LITERATURE/DATASHEET/CD00003202.pdf; DatasheetVersion: Apr-2008; Library Name: Symbol Library.SchLib; PackageDescription: 3-Pin SOT-23 Package 0.95 mm Pitch, 2.4 mm Lead Span; PackageReference: SOT23-3; PackageVersion: 2011; Supplier 1: Digi-Key; Supplier Part Number 1: 497-TS432AILTCT-ND
